# Altium schematic sheet water_system_module.SchDoc
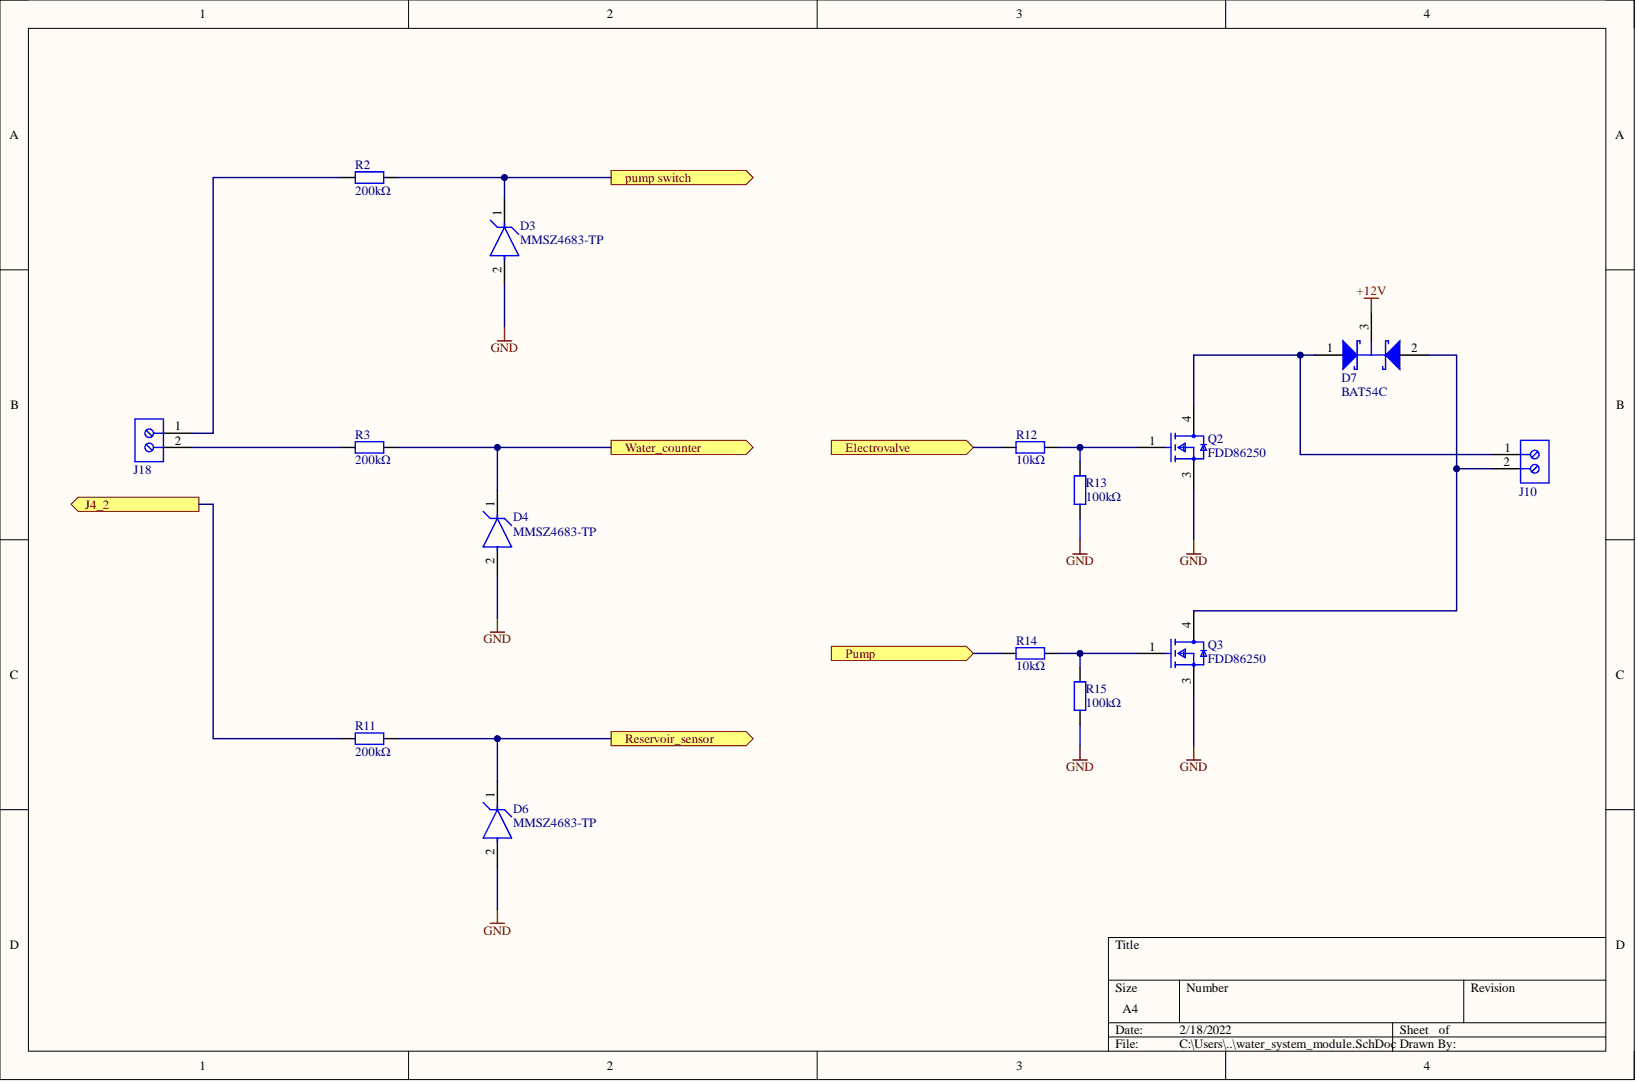
[diagram p0: water_system_module.SchDoc]

## Parts
D3  MMSZ4683-TP  [FP-SOD-123-MFG]
D4  MMSZ4683-TP  [FP-SOD-123-MFG]
D6  MMSZ4683-TP  [FP-SOD-123-MFG]
D7  BAT54C  [SOT-23]
J10  282836-2  [TECO-282836-2_V]  (value not drawn)
J18  282836-2  [TECO-282836-2_V]  (value not drawn)
Q2  FDD86250  [FP-369AS-MFG]
Q3  FDD86250  [FP-369AS-MFG]
R2  200kΩ  [RESC2013X50X30ML20T20]
R3  200kΩ  [RESC2013X50X30ML20T20]
R11  200kΩ  [RESC2013X50X30ML20T20]
R12  10kΩ  [RESC2013X50X30ML20T20]
R13  100kΩ  [RESC2013X50X30ML20T20]
R14  10kΩ  [RESC2013X50X30ML20T20]
R15  100kΩ  [RESC2013X50X30ML20T20]

## Ports
Electrovalve, J4_2, Pump, pump switch, Reservoir_sensor, Water_counter

## Nets
+12V: D7.3
GND: D3.2 D4.2 D6.2 Q2.3 Q3.3 R13.2 R15.2
Electrovalve: R12.2 ; port Electrovalve
J4_2: R11.2 ; port J4_2
Pump: R14.2 ; port Pump
pump switch: D3.1 R2.1 ; port pump switch
Reservoir_sensor: D6.1 R11.1 ; port Reservoir_sensor
Water_counter: D4.1 R3.2 ; port Water_counter
NetD7_1: D7.1 J10.1 Q2.4
NetD7_2: D7.2 J10.2 Q3.4
NetJ18_1: J18.1 R2.2
NetJ18_2: J18.2 R3.1
NetQ2_1: Q2.1 R12.1 R13.1
NetQ3_1: Q3.1 R14.1 R15.1

## Library data of the parts
D3 D4 D6 = CMP-56208-000004-1: DIODE ZENER 3V 500MW SOD123; Element Configuration: Single; Forward Voltage: 950mV; Max Reverse Leakage Current: 800nA; Tolerance: 5%; Component Kind: Standard; Lead Free: Lead Free; Library Name: Altium Content Vault; Max Operating Temperature: 150°C; Max Power Dissipation: 500mW; Min Operating Temperature: -55°C; Mount: Surface Mount; Number of Pins: 2; Package Quantity: 1; Packaging: Tape and Reel; Peak Reverse Current: 800nA; Power Dissipation: 500mW; Radiation Hardening: No; Voltage Tolerance: 5%; Working Voltage: 3V; Zener Voltage: 3V
D7 = DIODE_BAT54C: Schottky Barrier Diode, 30 V, 3-Pin SOT23, RoHS, Tape and Reel; Manufacturer: NXP Semiconductors; Common Connection: Cathode; Current Ifsm: 600mA; Forward Current If(AV): 200mA; Forward Voltage: 800mV; Junction Temperature Tj Max: 125degC; No. of Pins: 3; Package Version: Feb-16; Pin Configuration: 1A1,2A2,3comK; Reel Quantity: 3000; Repetitive Reverse Voltage Vrrm Max: 30V; Reverse Recovery Time trr Max: 5ns; SMD Marking: L43/*W1; SVHC: NoSVHC(19-Dec-2012); Component Kind: Standard; Datasheet URL: https://datasheet.ciiva.com/33723/1668625-33723936.pdf; Datasheet Version: Rev. 5 , 10/2012; Diode Case Style: SOT-23; Diode Type: Schottky; Forward Surge Current Ifsm Max: 600mA; Forward Voltage VF Max: 800mV; Library Name: integrated_library.IntLib; Manufacturer URL: http://www.nxp.com/; Operating Temperature Max: 150degC; Operating Temperature Min: -65degC; Operating Temperature Range: -65degCto+150degC; Package / Case: SOT-23; Package Description: 3-Pin Small Outline Transistor, Body 1.3 x 2.9 mm, Pitch 0.95 mm; Package Reference: SOT23-3; Package URL: http://www.nxp.com/documents/outline_drawing/SOT23.pdf; Reverse Recovery Time trr Typ: 5ns; Tape Width: 8mm; Termination Type: SMD
J10 J18 = CMP-1684-00017-1: Female Header, Pitch 5 mm, 1 x 2 Position, Height 10 mm, Tail Length 3.5 mm, Rohs, Bulk; Manufacturer: TE Connectivity; 3D Model: 282836-2.pdf; Clamp Material - Plating: Brass-NickelPlated; Current: 13.5A; Dynamic Catalog: BuchananSeries; Featured Product: EurostyleTerminalBlockConnectors; Mating Orientation: HorizontalwithBoard; Number of Levels: 1; Other Names: 5ULV-02B  A98076; Pitch: 0.197"(5.00mm); Positions Per Level: 2; Product Training Modules: Wire-to-BoardEurostyleTerminalBlocks; Screw Thread: M3; Torque - Screw: 0.68Nm(6Lb-In); Voltage: 300V; Wire Gauge: 16-30AWG; Wire Termination: Screw-RisingCageClamp; Category: Connectors,Interconnects; Color: Green; Component Kind: Standard; Contact Material - Plating: Brass-TinPlated; Datasheet URL: http://www.te.com.cn/commerce/DocumentDelivery/DDEController?Action=showdoc&DocId=Catalog+Section%7F1308389_EUROSTYLE_TERMINAL_BLOCKS%7F0607%7Fpdf%7FEnglish%7FENG_CS_1308389_EUROSTYLE_TERMINAL_BLOCKS_0607.pdf%7F282836-2; Family: TerminalBlocks-WiretoBoard; Features: Interlocking(Side); Housing Material: Polyamide(PA66),Nylon6/6; Library Name: Altium Content Vault; Manufacturer URL: http://www.te.com; Mounting Type: ThroughHole; Package Description: Female Header, Pitch 5 mm, 1 x 2 Position, Height 10 mm, Tail Length 3.5 mm; Package Reference: THD; Packaging: Bulk; RoHS Information: 282836-2StatementofCompliance; Screw Material - Plating: Brass-NickelPlated; Series: Buchanan; Standard Package: 300
Q2 Q3 = CMP-07173-000037-1: MOSFET N-CH 150V 8A DPAK; Manufacturer: ON Semiconductor; Depletion Mode: False; Drain To Source Resistance: 18.4mΩ; Gate Charge At Vgs: 23nC @ 10V; Gate To Source Voltage: 20V; Input Capacitace At Vds: 1585pF @ 75V; Max Forward Diode Voltage: 1.3V; Max Junction Temp: +150°C; Number Of Channels: 1; Power Dissipation: 3.1W; Pulse Drain Current: 164A; Reverse Recovery Charge: 104nC; Reverse Recovery Time: 71ns; Rthja Max: 40°C/W; Threshold Vgs Max: 4V; Threshold Vgs Min: 2V; Transconductance: 28S; Turn Off Delay Time: 20ns; Turn On Delay Time: 11.2ns; Typ Forward Diode Voltage: 0.78V; Automotive: No; Category: Trans; Component Kind: Standard; ComponentLink2Description: Datasheet; ComponentLink2URL: https://www.onsemi.com/pub/Collateral/FDD86250-D.pdf; Continuous Drain Current: 8A; Device Class L1: Discrete Semiconductors; Device Class L2: Transistors; Device Class L3: MOSFETs; Digikey Description: MOSFET N-CH 150V 8A DPAK; Drain To Source Breakdown Voltage: 150V; Drain To Source Voltage: 150V; Footprint Url: https://www.onsemi.com/pub/Collateral/369AS.PDF; Height: 2.517mm; Lead Free: Yes; Library Name: Altium Content Vault; Manufacturer Part Number: FDD86250; Mouser Description: Shielded Gate PowerTrench® MOSFET, N-Channel, 150 V, 51 A, 22 mO; Number Of N Channels: 1; Octopart: https://octopart.com/fdd86250-on+semiconductor-84325855; Package: 369AS; RoHS: TRUE; Temperature: -55°C to +150°C; Temperature Range High: +150°C; Temperature Range Low: -55°C
R2 R3 R11-R15 = Res_0805: Resistor; Component Kind: Standard; Library Name: PCB_Project.SchLib; Signal Integrity: Res; Simulation: RESISTOR
